# Altium schematic sheet MAIN.SchDoc(MAIN)
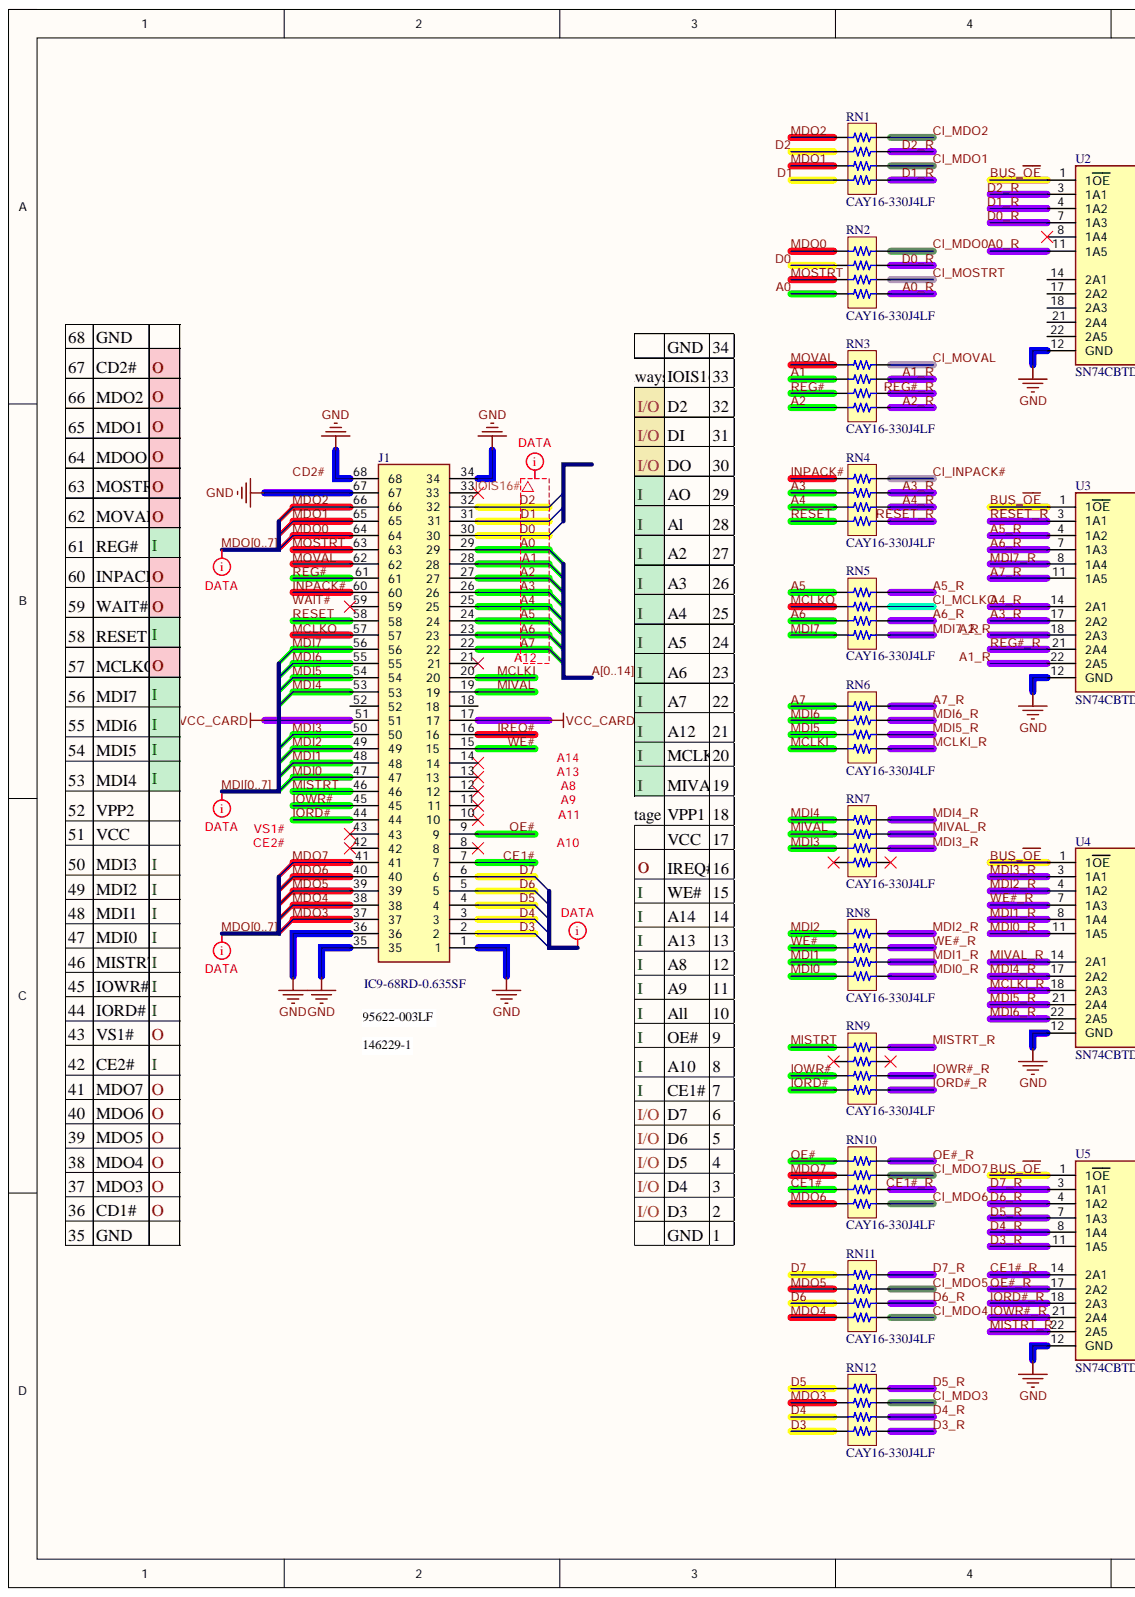
[diagram p0: MAIN.SchDoc, left]
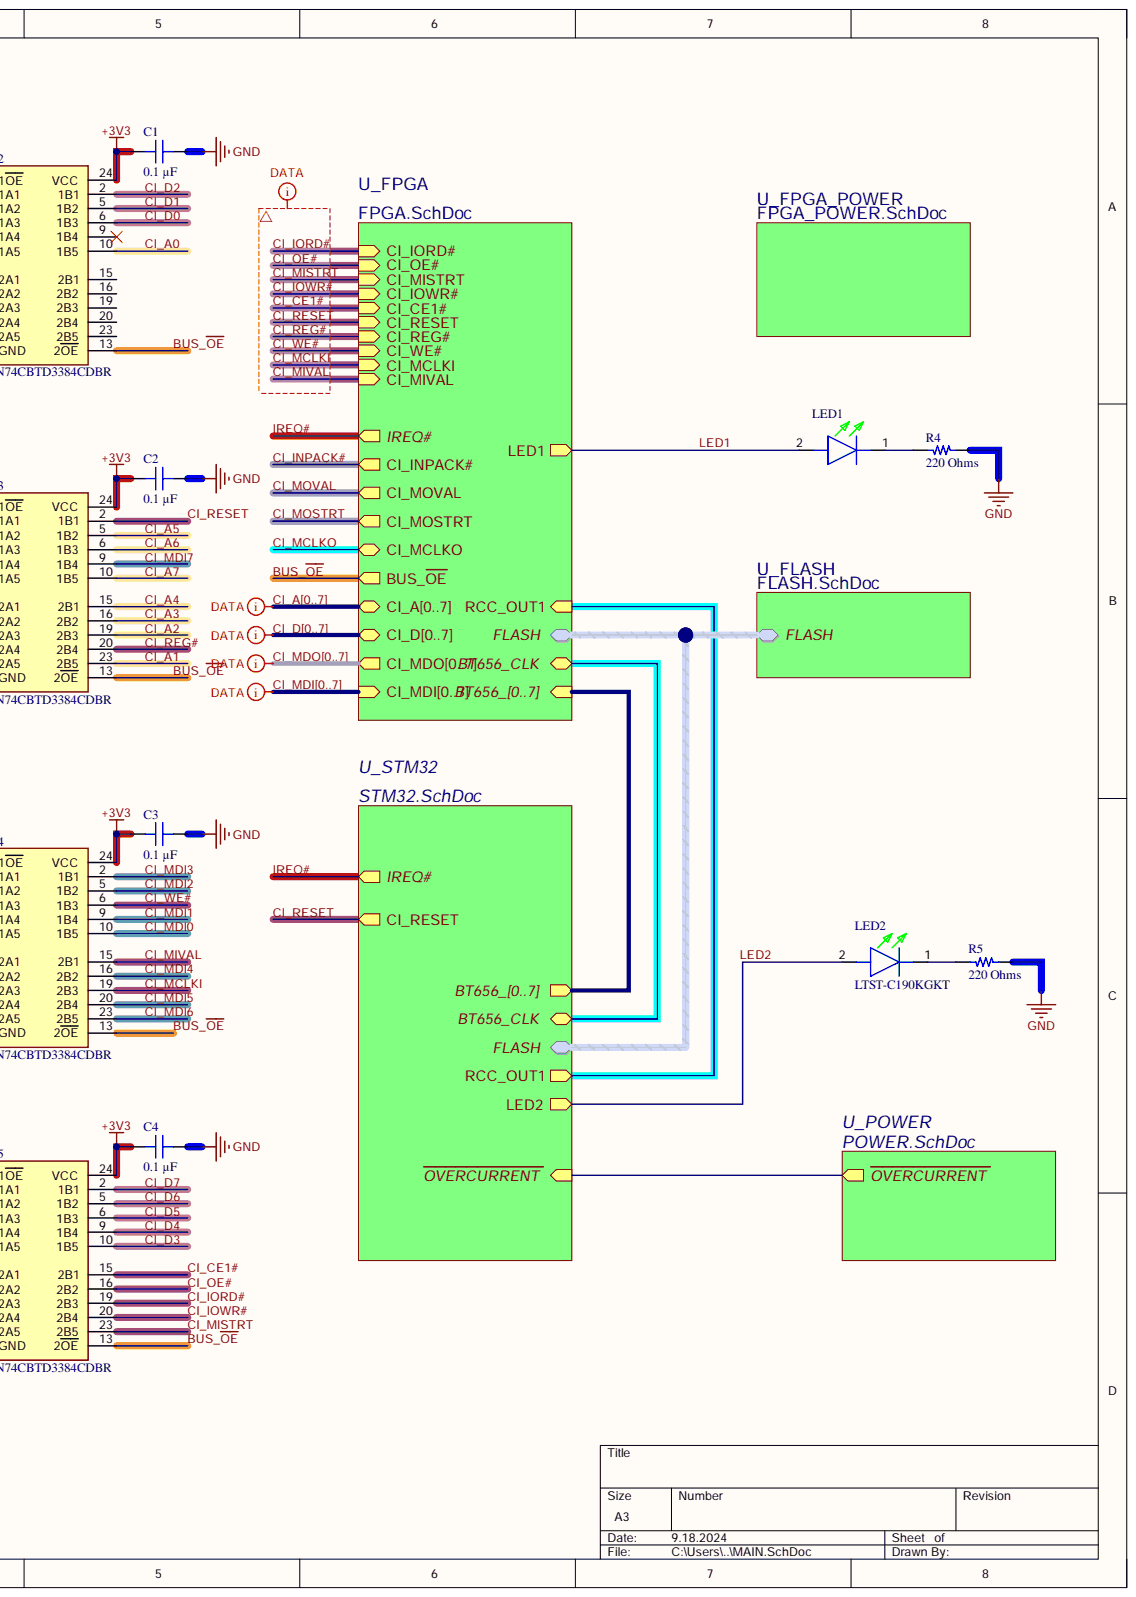
[diagram p1: MAIN.SchDoc, right]

## Parts
C1  0.1 µF  [CAP 0402_1005]
C2  0.1 µF  [CAP 0402_1005]
C3  0.1 µF  [CAP 0402_1005]
C4  0.1 µF  [CAP 0402_1005]
J1  IC9-68RD-0.635SF  [IC9-68RD-0.635SF]
LED1  (no value)  [LEDC1608X80N]
LED2  LTST-C190KGKT  [LEDC1608X80N]
R4  220 Ohms  [RES 0603_1608]
R5  220 Ohms  [RES 0603_1608]
RN1  CAY16-330J4LF  [RESNET 1206-8 CONVEX]
RN2  CAY16-330J4LF  [RESNET 1206-8 CONVEX]
RN3  CAY16-330J4LF  [RESNET 1206-8 CONVEX]
RN4  CAY16-330J4LF  [RESNET 1206-8 CONVEX]
RN5  CAY16-330J4LF  [RESNET 1206-8 CONVEX]
RN6  CAY16-330J4LF  [RESNET 1206-8 CONVEX]
RN7  CAY16-330J4LF  [RESNET 1206-8 CONVEX]
RN8  CAY16-330J4LF  [RESNET 1206-8 CONVEX]
RN9  CAY16-330J4LF  [RESNET 1206-8 CONVEX]
RN10  CAY16-330J4LF  [RESNET 1206-8 CONVEX]
RN11  CAY16-330J4LF  [RESNET 1206-8 CONVEX]
RN12  CAY16-330J4LF  [RESNET 1206-8 CONVEX]
U2  SN74CBTD3384CDBR  [SOP65P780X200-24N]
U3  SN74CBTD3384CDBR  [SOP65P780X200-24N]
U4  SN74CBTD3384CDBR  [SOP65P780X200-24N]
U5  SN74CBTD3384CDBR  [SOP65P780X200-24N]

## Nets
+3V3: C1.2 C2.2 C3.2 C4.2 U2.24(VCC) U3.24(VCC) U4.24(VCC) U5.24(VCC)
GND: C1.1 C2.1 C3.1 C4.1 J1.1 J1.34 J1.35 J1.36 J1.67 J1.68 R4.2 R5.2 U2.12(GND) U3.12(GND) U4.12(GND) U5.12(GND)
VCC_CARD: J1.17 J1.51
A0: J1.29 RN2.4  [also labelled A]
A0_R: RN2.5 U2.11(1A5)
A1: J1.28 RN3.2  [also labelled A]
A1_R: RN3.7 U3.22(2A5)
A2: J1.27 RN3.4  [also labelled A]
A2_R: RN3.5 U3.18(2A3)
A3: J1.26 RN4.2  [also labelled A]
A3_R: RN4.7 U3.17(2A2)
A4: J1.25 RN4.3  [also labelled A]
A4_R: RN4.6 U3.14(2A1)
A5: J1.24 RN5.1  [also labelled A]
A5_R: RN5.8 U3.4(1A2)
A6: J1.23 RN5.3  [also labelled A]
A6_R: RN5.6 U3.7(1A3)
A7: J1.22 RN6.1  [also labelled A]
A7_R: RN6.8 U3.11(1A5)
BUS_~{OE}: U2.1(1OE) U2.13(2OE) U3.1(1OE) U3.13(2OE) U4.1(1OE) U4.13(2OE) U5.1(1OE) U5.13(2OE)
CE1#: J1.7 RN10.3
CE1#_R: RN10.6 U5.14(2A1)
CI_A0: U2.10(1B5)  [also labelled CI_A]
CI_A1: U3.23(2B5)  [also labelled CI_A]
CI_A2: U3.19(2B3)  [also labelled CI_A]
CI_A3: U3.16(2B2)  [also labelled CI_A]
CI_A4: U3.15(2B1)  [also labelled CI_A]
CI_A5: U3.5(1B2)  [also labelled CI_A]
CI_A6: U3.6(1B3)  [also labelled CI_A]
CI_A7: U3.10(1B5)  [also labelled CI_A]
CI_CE1#: U5.15(2B1)
CI_D0: U2.6(1B3)  [also labelled CI_D]
CI_D1: U2.5(1B2)  [also labelled CI_D]
CI_D2: U2.2(1B1)  [also labelled CI_D]
CI_D3: U5.10(1B5)  [also labelled CI_D]
CI_D4: U5.9(1B4)  [also labelled CI_D]
CI_D5: U5.6(1B3)  [also labelled CI_D]
CI_D6: U5.5(1B2)  [also labelled CI_D]
CI_D7: U5.2(1B1)  [also labelled CI_D]
CI_INPACK#: RN4.8
CI_IORD#: U5.19(2B3)
CI_IOWR#: U5.20(2B4)
CI_MCLKI: U4.19(2B3)
CI_MCLKO: RN5.7
CI_MDI0: U4.10(1B5)  [also labelled CI_MDI]
CI_MDI1: U4.9(1B4)  [also labelled CI_MDI]
CI_MDI2: U4.5(1B2)  [also labelled CI_MDI]
CI_MDI3: U4.2(1B1)  [also labelled CI_MDI]
CI_MDI4: U4.16(2B2)  [also labelled CI_MDI]
CI_MDI5: U4.20(2B4)  [also labelled CI_MDI]
CI_MDI6: U4.23(2B5)  [also labelled CI_MDI]
CI_MDI7: U3.9(1B4)  [also labelled CI_MDI]
CI_MDO0: RN2.8  [also labelled CI_MDO]
CI_MDO1: RN1.6  [also labelled CI_MDO]
CI_MDO2: RN1.8  [also labelled CI_MDO]
CI_MDO3: RN12.7  [also labelled CI_MDO]
CI_MDO4: RN11.5  [also labelled CI_MDO]
CI_MDO5: RN11.7  [also labelled CI_MDO]
CI_MDO6: RN10.5  [also labelled CI_MDO]
CI_MDO7: RN10.7  [also labelled CI_MDO]
CI_MISTRT: U5.23(2B5)
CI_MIVAL: U4.15(2B1)
CI_MOSTRT: RN2.6
CI_MOVAL: RN3.8
CI_OE#: U5.16(2B2)
CI_REG#: U3.20(2B4)
CI_RESET: U3.2(1B1)
CI_WE#: U4.6(1B3)
D0: J1.30 RN2.2
D0_R: RN2.7 U2.7(1A3)
D1: J1.31 RN1.4
D1_R: RN1.5 U2.4(1A2)
D2: J1.32 RN1.2
D2_R: RN1.7 U2.3(1A1)
D3: J1.2 RN12.4
D3_R: RN12.5 U5.11(1A5)
D4: J1.3 RN12.3
D4_R: RN12.6 U5.8(1A4)
D5: J1.4 RN12.1
D5_R: RN12.8 U5.7(1A3)
D6: J1.5 RN11.3
D6_R: RN11.6 U5.4(1A2)
D7: J1.6 RN11.1
D7_R: RN11.8 U5.3(1A1)
INPACK#: J1.60 RN4.1
IORD#: J1.44 RN9.4
IORD#_R: RN9.5 U5.18(2A3)
IOWR#: J1.45 RN9.3
IOWR#_R: RN9.6 U5.21(2A4)
IREQ#: J1.16
LED1: LED1.2
LED2: LED2.2
MCLKI: J1.20 RN6.4
MCLKI_R: RN6.5 U4.18(2A3)
MCLKO: J1.57 RN5.2
MDI0: J1.47 RN8.4  [also labelled MDI]
MDI0_R: RN8.5 U4.11(1A5)
MDI1: J1.48 RN8.3  [also labelled MDI]
MDI1_R: RN8.6 U4.8(1A4)
MDI2: J1.49 RN8.1  [also labelled MDI]
MDI2_R: RN8.8 U4.4(1A2)
MDI3: J1.50 RN7.3  [also labelled MDI]
MDI3_R: RN7.6 U4.3(1A1)
MDI4: J1.53 RN7.1  [also labelled MDI]
MDI4_R: RN7.8 U4.17(2A2)
MDI5: J1.54 RN6.3  [also labelled MDI]
MDI5_R: RN6.6 U4.21(2A4)
MDI6: J1.55 RN6.2  [also labelled MDI]
MDI6_R: RN6.7 U4.22(2A5)
MDI7: J1.56 RN5.4  [also labelled MDI]
MDI7_R: RN5.5 U3.8(1A4)
MDO0: J1.64 RN2.1  [also labelled MDO]
MDO1: J1.65 RN1.3  [also labelled MDO]
MDO2: J1.66 RN1.1  [also labelled MDO]
MDO3: J1.37 RN12.2  [also labelled MDO]
MDO4: J1.38 RN11.4  [also labelled MDO]
MDO5: J1.39 RN11.2  [also labelled MDO]
MDO6: J1.40 RN10.4  [also labelled MDO]
MDO7: J1.41 RN10.2  [also labelled MDO]
MISTRT: J1.46 RN9.1
MISTRT_R: RN9.8 U5.22(2A5)
MIVAL: J1.19 RN7.2
MIVAL_R: RN7.7 U4.14(2A1)
MOSTRT: J1.63 RN2.3
MOVAL: J1.62 RN3.1
OE#: J1.9 RN10.1
OE#_R: RN10.8 U5.17(2A2)
REG#: J1.61 RN3.3
REG#_R: RN3.6 U3.21(2A4)
RESET: J1.58 RN4.4
RESET_R: RN4.5 U3.3(1A1)
WE#: J1.15 RN8.2
WE#_R: RN8.7 U4.7(1A3)
NetJ1_8: J1.8
NetJ1_10: J1.10
NetJ1_11: J1.11
NetJ1_12: J1.12
NetJ1_13: J1.13
NetJ1_14: J1.14
NetJ1_18: J1.18
NetJ1_21: J1.21
NetJ1_33: J1.33
NetJ1_42: J1.42
NetJ1_43: J1.43
NetJ1_52: J1.52
NetJ1_59: J1.59
NetLED1_1: LED1.1 R4.1
NetLED2_1: LED2.1 R5.1
NetRN7_4: RN7.4
NetRN7_5: RN7.5
NetRN9_2: RN9.2
NetRN9_7: RN9.7
NetU2_8: U2.8(1A4)
NetU2_9: U2.9(1B4)
NetU2_14: U2.14(2A1)
NetU2_15: U2.15(2B1)
NetU2_16: U2.16(2B2)
NetU2_17: U2.17(2A2)
NetU2_18: U2.18(2A3)
NetU2_19: U2.19(2B3)
NetU2_20: U2.20(2B4)
NetU2_21: U2.21(2A4)
NetU2_22: U2.22(2A5)
NetU2_23: U2.23(2B5)
~{OVERCURRENT}:
A8:  [also labelled A]
A9:  [also labelled A]
A10:  [also labelled A]
A11:  [also labelled A]
A12:  [also labelled A]
A13:  [also labelled A]
A14:  [also labelled A]
BT656_0:
BT656_1:
BT656_2:
BT656_3:
BT656_4:
BT656_5:
BT656_6:
BT656_7:
BT656_CLK:
CD2#:
FLASH.FLASH_CS:
FLASH.FLASH_MISO:
FLASH.FLASH_MOSI:
FLASH.FLASH_SCK:
RCC_OUT1:
WAIT#:

## Library data of the parts
C1-C4 = CC0402KRX7R7BB104: CAP CER 0.1UF 16V X7R 0402; manufacturer: Yageo; Database Table Name: Capacitors - Ceramic - 0402; Tolerance: ±10%; Applications: General Purpose; Capacitance: 0.1 µF; Component Kind: Standard; componentlink1description: Datasheet; componentlink1url: https://www.yageo.com/upload/media/product/productsearch/datasheet/mlcc/UPY-GPHC_X7R_6.3V-to-50V_18.pdf; componentlink2description: DigiKey Link; componentlink2url: https://www.digikey.com/en/products/detail/yageo/CC0402KRX7R7BB104/2103076; Device Package: 0402 (1005 Metric); lastupdated: 09.02.2024 20:04:34; Library Name: Celestial Altium Library - altium_library.DbLib; Lifecycle Status: Active; Minimum Order: 10; Mounting Type: Surface Mount, MLCC; Operating Temperature: -55°C ~ 125°C; Package / Case: 0402 (1005 Metric); packaging: TapeAndReel; Part Number: CC0402KRX7R7BB104; Part Status: Active; partid: 4014; price: 0.01; series: CC; Size / Dimension: 0.039" L x 0.020" W (1.00mm x 0.50mm); Supplier 1: DigiKey; Supplier Part Number 1: 311-1338-1-ND; Temperature Coefficient: X7R; Thickness (Max): 0.022" (0.55mm); Value: 0.1 µF; Voltage - Rated: 16V
J1 = IC9-68RD-0.635SF: Connector Card Bus Socket; Manufacturer_Name: Hirose; Component Kind: Standard; Height: 2.83mm; Library Name: SamacSys.SchLib; Manufacturer_Part_Number: IC9-68RD-0.635SF
LED1 LED2 = LTST-C190KGKT: LED, 0603, GREEN; Manufacturer_Name: Lite-On; Arrow Part Number: LTST-C190KGKT; Arrow Price/Stock: https://www.arrow.com/en/products/ltst-c190kgkt/lite-on-technology?region=europe; Component Kind: Standard; Datasheet Link: http://uk.rs-online.com/web/p/visible-leds/6920988/; Height: 0.8mm; Library Name: SamacSys.SchLib; Manufacturer_Part_Number: LTST-C190KGKT; Mouser Part Number: 859-LTST-C190KGKT; Mouser Price/Stock: https://www.mouser.co.uk/ProductDetail/Lite-On/LTST-C190KGKT?qs=205qCy6M2Q%252BAdcgwUZktqw%3D%3D
R4 R5 = RC0603FR-07220RL: RES 220 OHM 1% 1/10W 0603; Manufacturer: Yageo; Database Table Name: Resistors - Surface Mount - 0603; Power (Watts): 0.1W, 1/10W; Temperature Coefficient: ±100ppm/°C; Component Kind: Standard; ComponentLink1Description: Datasheet; ComponentLink1URL: https://www.yageo.com/upload/media/product/productsearch/datasheet/rchip/PYu-RC_Group_51_RoHS_L_10.pdf; ComponentLink2Description: DigiKey Link; ComponentLink2URL: https://www.digikey.com/en/products/detail/yageo/RC0603FR-07220RL/727061; Composition: Thick Film; Device Package: 0603 (1608 Metric); Features: Moisture Resistant; Height - Seated (Max): 0.022" (0.55mm); LastUpdated: 09.02.2024 20:42:42; Library Name: Celestial Altium Library - altium_library.DbLib; Lifecycle Status: Active; Minimum Order: 1; Number of Terminations: 2; Operating Temperature: -55°C ~ 155°C; Package / Case: 0603 (1608 Metric); Packaging: CutTape; Part Number: RC0603FR-07220RL; Part Status: Active; PartId: 18935; Price: 0.01; Resistance: 220 Ohms; Series: RC_L; Size / Dimension: 0.063" L x 0.031" W (1.60mm x 0.80mm); Supplier 1: DigiKey; Supplier Device Package: 0603; Supplier Part Number 1: 311-220HRCT-ND; Tolerance: ±1%; Value: 220 Ohms
RN1-RN12 = CAY16-330J4LF: RES ARRAY 4 RES 33 OHM 1206; Manufacturer: Bourns Inc.; Circuit Type: Isolated; Database Table Name: Resistors -  Arrays and Networks; Number of Resistors: 4; Power Per Element: 62.5mW; Resistance (Ohms): 33; Temperature Coefficient: ±200ppm/°C; Tolerance: ±5%; Component Kind: Standard; ComponentLink1Description: Datasheet; ComponentLink1URL: https://www.bourns.com/docs/Product-Datasheets/CATCAY.pdf; ComponentLink2Description: DigiKey Link; ComponentLink2URL: http://digikey.com/product-detail/en/bourns-inc/CAY16-330J4LF/CAY16-330J4LFCT-ND/3437924; Height - Seated (Max): 0.024" (0.60mm); LastUpdated: 02.09.2020 1:23:24; Library Name: Celestial Altium Library - altium_library.DbLib; Lifecycle Status: Active; Minimum Order: 1; Mounting Type: Surface Mount; Number of Pins: 8; Operating Temperature: -55°C ~ 125°C; Package / Case: 1206 (3216 Metric), Convex, Long Side Terminals; Packaging: TapeAndReel; Part Number: CAY16-330J4LF; Part Status: Active; PartId: 158; Price: 0.01; Series: CAY16; Size / Dimension: 0.126" L x 0.063" W (3.20mm x 1.60mm); Supplier 1: DigiKey; Supplier Device Package: 1206; Supplier Part Number 1: CAY16-330J4LFCT-ND
U2-U5 = SN74CBTD3384CDBR: Integrated Circuit; Manufacturer_Name: Texas Instruments; Component Kind: Standard; Datasheet Link: http://www.ti.com/lit/gpn/sn74cbtd3384c; Height: 2mm; Library Name: SamacSys.SchLib; Manufacturer_Part_Number: SN74CBTD3384CDBR; Mouser Part Number: 595-SN74CBTD3384CDBR; Mouser Price/Stock: https://www.mouser.co.uk/ProductDetail/Texas-Instruments/SN74CBTD3384CDBR?qs=mE33ZKBHyE5HkNXzDF2trA%3D%3D
